# Altium schematic sheet Power.SchDoc
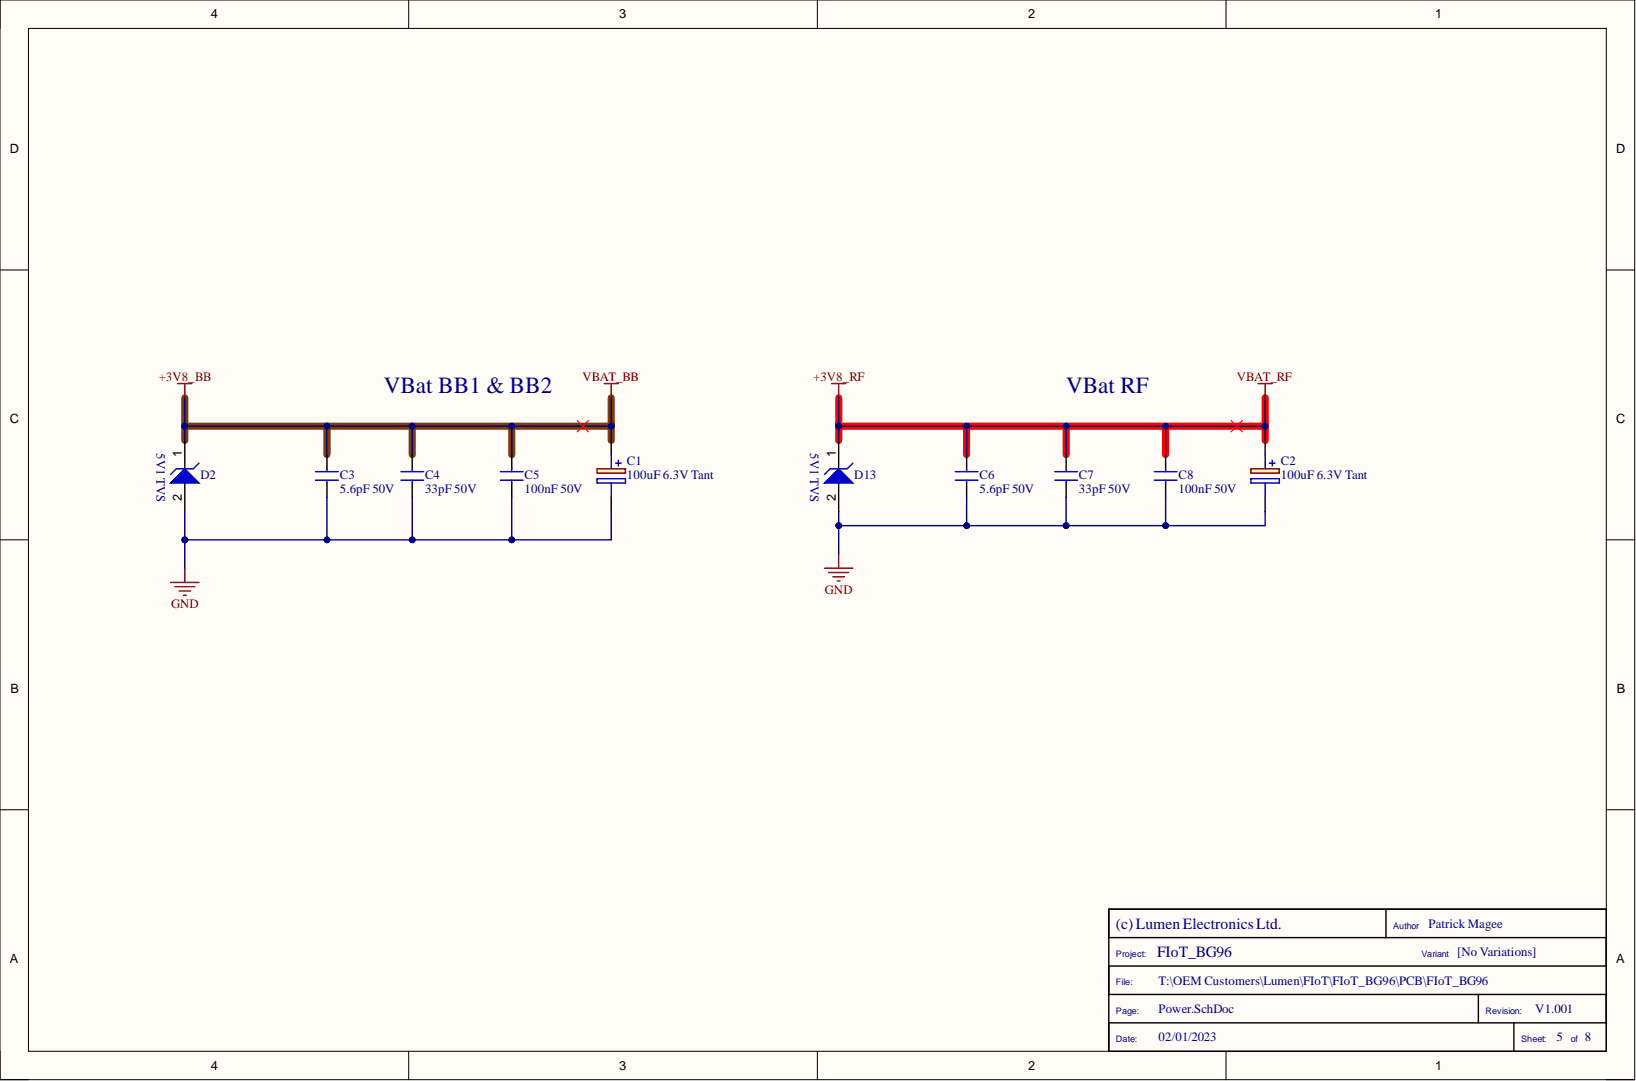
[diagram p0: Power.SchDoc]

## Parts
C1  value 100uF 6.3V Tant  [CAPPM3216X180N]  (hidden comment: T520A107M006ATE035)
C2  value 100uF 6.3V Tant  [CAPPM3216X180N]  (hidden comment: T520A107M006ATE035)
C3  value 5.6pF 50V  [CAPC1005X06N]  (hidden comment: GRM1555C1H6R0CA01D)
C4  value 33pF 50V  [CAPC1005X06N]  (hidden comment: GJM1555C1H330GB01D)
C5  value 100nF 50V  [CAPC1005X06N]  (hidden comment: CL05B104KB54PNC)
C6  value 5.6pF 50V  [CAPC1005X06N]  (hidden comment: GRM1555C1H6R0CA01D)
C7  value 33pF 50V  [CAPC1005X06N]  (hidden comment: GJM1555C1H330GB01D)
C8  value 100nF 50V  [CAPC1005X06N]  (hidden comment: CL05B104KB54PNC)
D2  PZ3D4V2H  [CR_SD05_SEM-L]  (value not drawn)
D13  PZ3D4V2H  [CR_SD05_SEM-L]  (value not drawn)

## Nets
+3V8_BB: C1.1 C3.2 C4.2 C5.2 D2.1
+3V8_RF: C2.1 C6.2 C7.2 C8.2 D13.1
GND: C1.2 C2.2 C3.1 C4.1 C5.1 C6.1 C7.1 C8.1 D2.2 D13.2

## Library data of the parts
C1 C2 = T520A107M006ATE035: Tantalum Capacitors - Polymer 6.3 VDC 100uF 1206 20% ESR=35mOhms; Manufacturer_Name: Kemet; Arrow Part Number: T520A107M006ATE035; Arrow Price/Stock: https://www.arrow.com/en/products/t520a107m006ate035/kemet-corporation; Component Kind: Standard; Datasheet Link: https://search.kemet.com/component-edge/download/specsheet/T520A107M006ATE035.pdf; Height: 1.8mm; JLC Footprint: Not available with JLC; JLCPCB Part #: Not available with JLC; Library Name: FIoT_BG96.SCHLIB; Manufacturer_Part_Number: T520A107M006ATE035; MFG Name: Kemet; MFG Part Number: T520A107M006ATE035; Mouser Part Number: 80-T520A107M006AT; Mouser Price/Stock: https://www.mouser.co.uk/ProductDetail/KEMET/T520A107M006ATE035?qs=QvRObDHRS18agZVs%252BDin8A%3D%3D; Supplier 1: Farnell; Supplier 2: Mouser; Supplier Part Number 1: 2855176; Supplier Part Number 2: 80-T520A107M006AT
C3 C6 = CC0402BRNPO9BN5R6: 5.6pF 50V NP0 ±0.1pF 0402 MLCC; Manufacturer: TDK; Manufacturer Part Number: C1005C0G1H060C; MFG Name: Murata; Component Kind: Standard; JLC Footprint: CAP-SMD; JLCPCB Part #: C162118; Library Name: FIoT_BG96.SCHLIB; MFG Part Number: GRM1555C1H6R0CA01D; Part Number: GRM1555C1H6R0CA01D; Supplier 1: Digi-Key; Supplier 2: Mouser; Supplier Part Number 1: 490-8222-1-ND; Supplier Part Number 2: 81-GRM1555C1H6R0CA1D; Value: 5.6pF 50V
C4 C7 = GJM1555C1H330GB01D: 33pF 50V C0G ±2% 0402 MLCC; Manufacturer: TDK; Manufacturer Part Number: C1005C0G1H060C; MFG Part Number: GRM1555C1H6R0CA01D; MFG Name: Murata; Component Kind: Standard; JLC Footprint: CAP-SMD; JLCPCB Part #: C162118; Library Name: FIoT_BG96.SCHLIB; Part Number: GRM1555C1H6R0CA01D; Supplier 1: Farnell; Supplier 2: Digi-Key; Supplier 3: Mouser; Supplier Part Number 1: 3784396; Supplier Part Number 2: 490-GJM1555C1H330GB01DCT-ND; Supplier Part Number 3: 81-GJM1555C1H330GB1D
C5 C8 = CL05B104KB54PNC: 100nF 50V X7R ±10% 0402 MLCC; Manufacturer: TDK; Manufacturer Part Number: C1005C0G1H060C; MFG Part Number: GRM1555C1H6R0CA01D; MFG Name: Murata; Component Kind: Standard; JLC Footprint: CAP-SMD; JLCPCB Part #: C162118; Library Name: FIoT_BG96.SCHLIB; Part Number: GRM1555C1H6R0CA01D; Supplier 1: Digi-Key; Supplier 2: Mouser; Supplier Part Number 1: 1276-CL05B104KB54PNCCT-ND; Supplier Part Number 2: 187-CL05B104KB54PNC
D2 D13 = PZ3D4V2H: 5uA@4.2V 55Ω Single 500mW 5.1V SOD-323 Zener Diodes ROHS; Manufacturer_Part_Number: SD12C.TCT; Mfr_Name: Semtech; Type: DEV; Component Kind: Standard; Library Name: FIoT_BG96.SCHLIB; RefDes: RefDes; Copyright: Copyright (C) 2022 Ultra Librarian. All rights reserved.
